# Altium schematic sheet Schematic1.SchDoc(Schematic1)
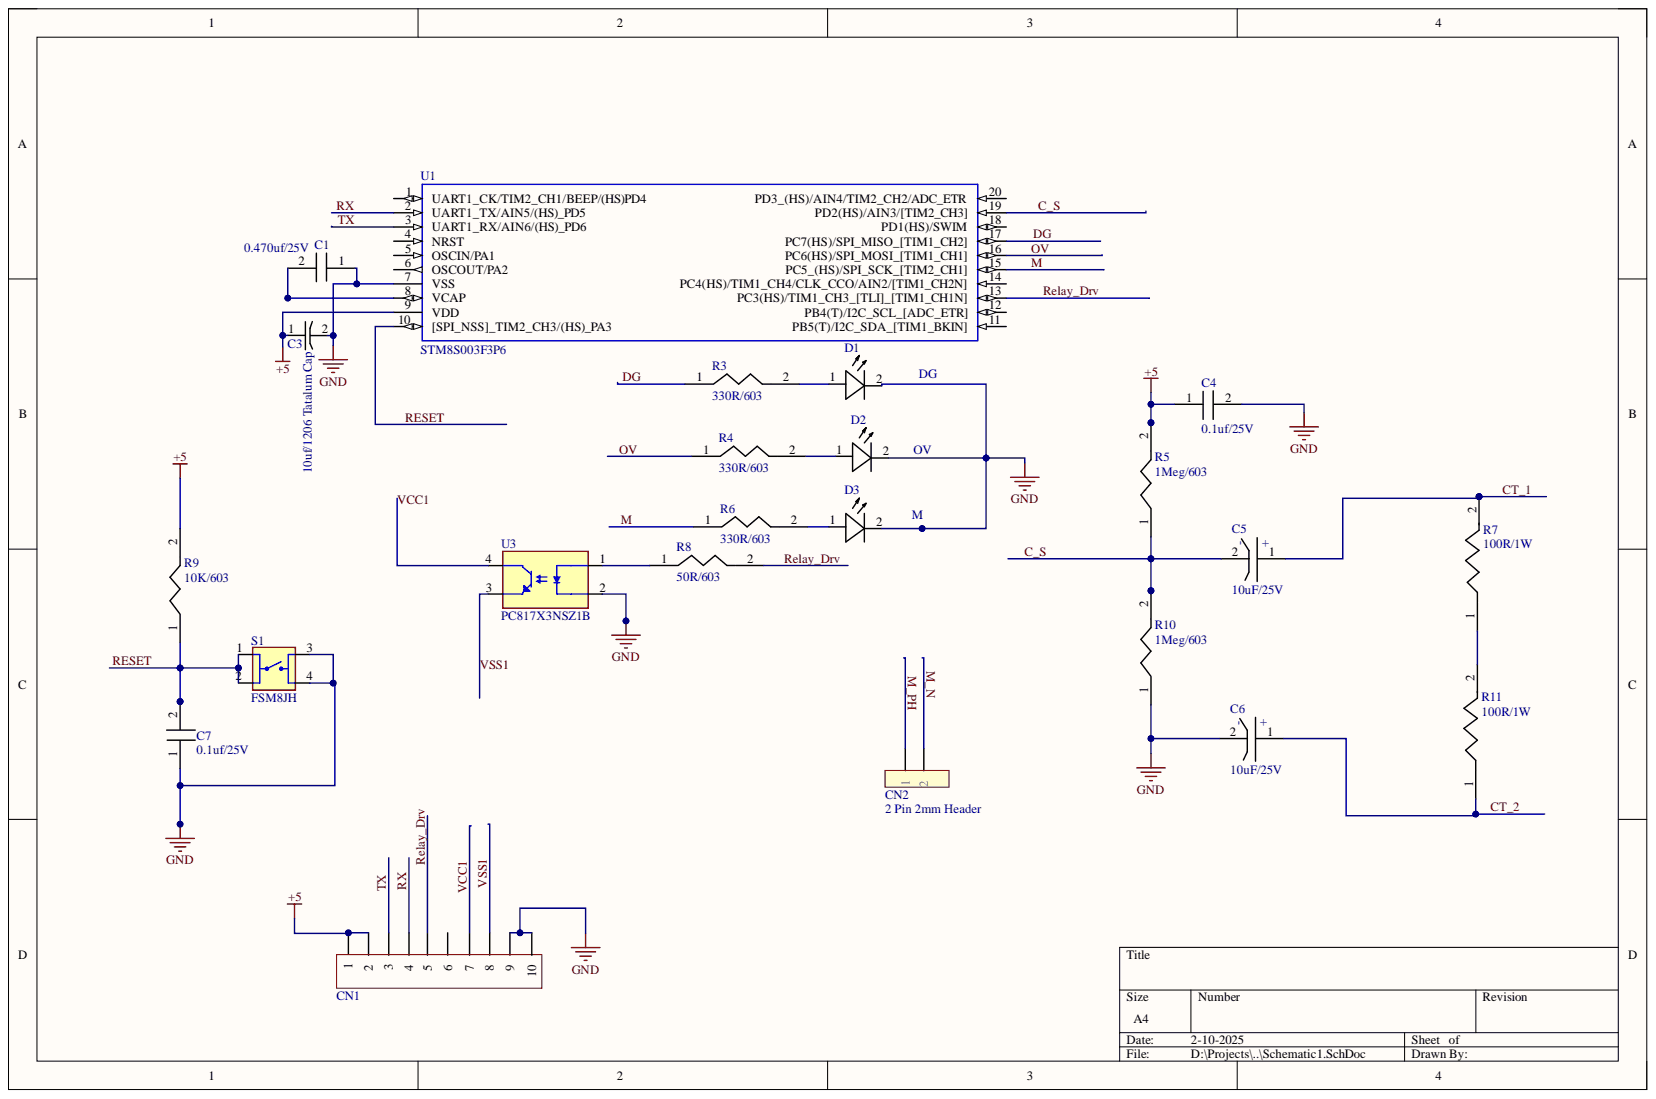
[diagram p0: Schematic1.SchDoc]

## Parts
C1  0.470uf/25V  [603]
C3  10uf/1206 Tatalum Cap  [1206 POL CAP]
C4  0.1uf/25V  [603]
C5  10uF/25V  [Electrolytic cap_6mm]
C6  10uF/25V  [Electrolytic cap_6mm]
C7  0.1uf/25V  [603]
CN1  (no value)  [10 Pin 2.5mm picht relimate connector]
CN2  2 Pin 2mm Header  [2mm 2 pin header]
D1  (no value)  [603 SMD LED]
D2  (no value)  [603 SMD LED]
D3  (no value)  [603 SMD LED]
R3  330R/603  [603]
R4  330R/603  [603]
R5  1Meg/603  [603]
R6  330R/603  [603]
R7  100R/1W  [1W Resistor]
R8  50R/603  [603]
R9  10K/603  [603]
R10  1Meg/603  [603]
R11  100R/1W  [1W Resistor]
S1  FSM8JH  [SW_FSM8JH]
U1  STM8S003F3P6  [SOP65P640X120-20N]
U3  PC817X3NSZ1B  [DIP762W50P254L495H455Q4]

## Nets
+5: C3.1 C4.1 CN1.1 CN1.2 R5.2 R9.2 U1.9(VDD)
GND: C1.1 C3.2 C4.2 C6.2 C7.1 CN1.9 CN1.10 D1.2 D2.2 D3.2 R10.1 S1.3 S1.4 U1.7(VSS) U3.2
C_S: C5.2 R5.1 R10.2 U1.19(PD2(HS)/AIN3/[TIM2_CH3])
CT_1: C5.1 R7.2
CT_2: C6.1 R11.1
DG: R3.1 U1.17(PC7(HS)/SPI_MISO_[TIM1_CH2])
M: R6.1 U1.15(PC5_(HS)/SPI_SCK_[TIM2_CH1])
M_N: CN2.2
M_PH: CN2.1
OV: R4.1 U1.16(PC6(HS)/SPI_MOSI_[TIM1_CH1])
Relay_Drv: CN1.5 R8.2 U1.13(PC3(HS)/TIM1_CH3_[TLI]_[TIM1_CH1N])
RESET: C7.2 R9.1 S1.1 S1.2 U1.10([SPI_NSS]_TIM2_CH3/(HS)_PA3)
RX: CN1.4 U1.2(UART1_TX/AIN5/(HS)_PD5)
TX: CN1.3 U1.3(UART1_RX/AIN6/(HS)_PD6)
VCC1: CN1.7 U3.4
VSS1: CN1.8 U3.3
NetC1_2: C1.2 U1.8(VCAP)
NetD1_1: D1.1 R3.2
NetD2_1: D2.1 R4.2
NetD3_1: D3.1 R6.2
NetR7_1: R7.1 R11.2
NetR8_1: R8.1 U3.1

Not connected: CN1 6; U1 1(UART1_CK/TIM2_CH1/BEEP/(HS)PD4) 4(NRST) 5(OSCIN/PA1) 6(OSCOUT/PA2) 11(PB5(T)/I2C_SDA_[TIM1_BKIN]) 12(PB4(T)/I2C_SCL_[ADC_ETR]) 14(PC4(HS)/TIM1_CH4/CLK_CCO/AIN2/[TIM1_CH2N]) 18(PD1(HS)/SWIM) 20(PD3_(HS)/AIN4/TIM2_CH2/ADC_ETR)

## Library data of the parts
C1 C4 C7 = 603 SMD CAP: Component Kind: Standard; Library Name: Custom1.IntLib
C3 = 1206 POL CAP: Component Kind: Standard; Library Name: Custom1.IntLib
C5 C6 = 6mm Electolytic cap: Component Kind: Standard; Library Name: Custom1.IntLib
CN1 = 10 Pin 2.5mm Pitch Relimate connector: System: Connectors; Component Kind: Standard; Library Name: Custom1.IntLib
CN2 = 2_PIN 2mm Header: Component Kind: Standard; Library Name: Custom1.IntLib
D1-D3 = 603 LED: Component Kind: Standard; Library Name: Custom1.IntLib
R3-R6 R8-R10 = 603 Resistor: Component Kind: Standard; Library Name: Custom1.IntLib
R7 R11 = 1W carbon Resistor: Component Kind: Standard; Library Name: Custom1.IntLib
S1 = FSM8JH: Tactile Switch SPST-NO Top Actuated Through Hole; MF: TE Connectivity; Availability: In Stock; Check_prices: https://www.snapeda.com/parts/FSM8JH/TE+Connectivity+ALCOSWITCH+Switches/view-part/?ref=eda; Component Kind: Standard; Library Name: FSM8JH.IntLib; MP: FSM8JH; Package: None; Price: None; SnapEDA_Link: https://www.snapeda.com/parts/FSM8JH/TE+Connectivity+ALCOSWITCH+Switches/view-part/?ref=snap
U1 = STM8S003F3P6: STM8 STM8S Microcontroller IC 8-Bit 16MHz 8KB _8K x 8_ FLASH 20-TSSOP; MF: STMicroelectronics; Availability: Unavailable; Component Kind: Standard; Library Name: STM8S003F3P6.IntLib; MP: STM8S003F3P6; Package: TSSOP-20 STMicroelectronics; Price: None; SnapEDA_Link: https://www.snapeda.com/parts/STM8S003F3P6/STMicroelectronics/view-part/47650/?ref=snap
U3 = PC817X3NSZ1B: Optoisolator Transistor Output - 1 Channel 4-DIP; MF: Sharp Microelectronics; Availability: In Stock; Check_prices: https://www.snapeda.com/parts/PC817X3NSZ1B/Sharp+Microelectronics/view-part/?ref=eda; Component Kind: Standard; Library Name: PC817X3NSZ1B.IntLib; MP: PC817X3NSZ1B; Package: DIP-4 Sharp Microelectronics; Price: None; Purchase-URL: https://pricing.snapeda.com/search/part/PC817X3NSZ1B/?ref=eda; SnapEDA_Link: https://www.snapeda.com/parts/PC817X3NSZ1B/Sharp+Microelectronics/view-part/?ref=snap
